# Altium schematic sheet schematic.SchDoc(schematic)
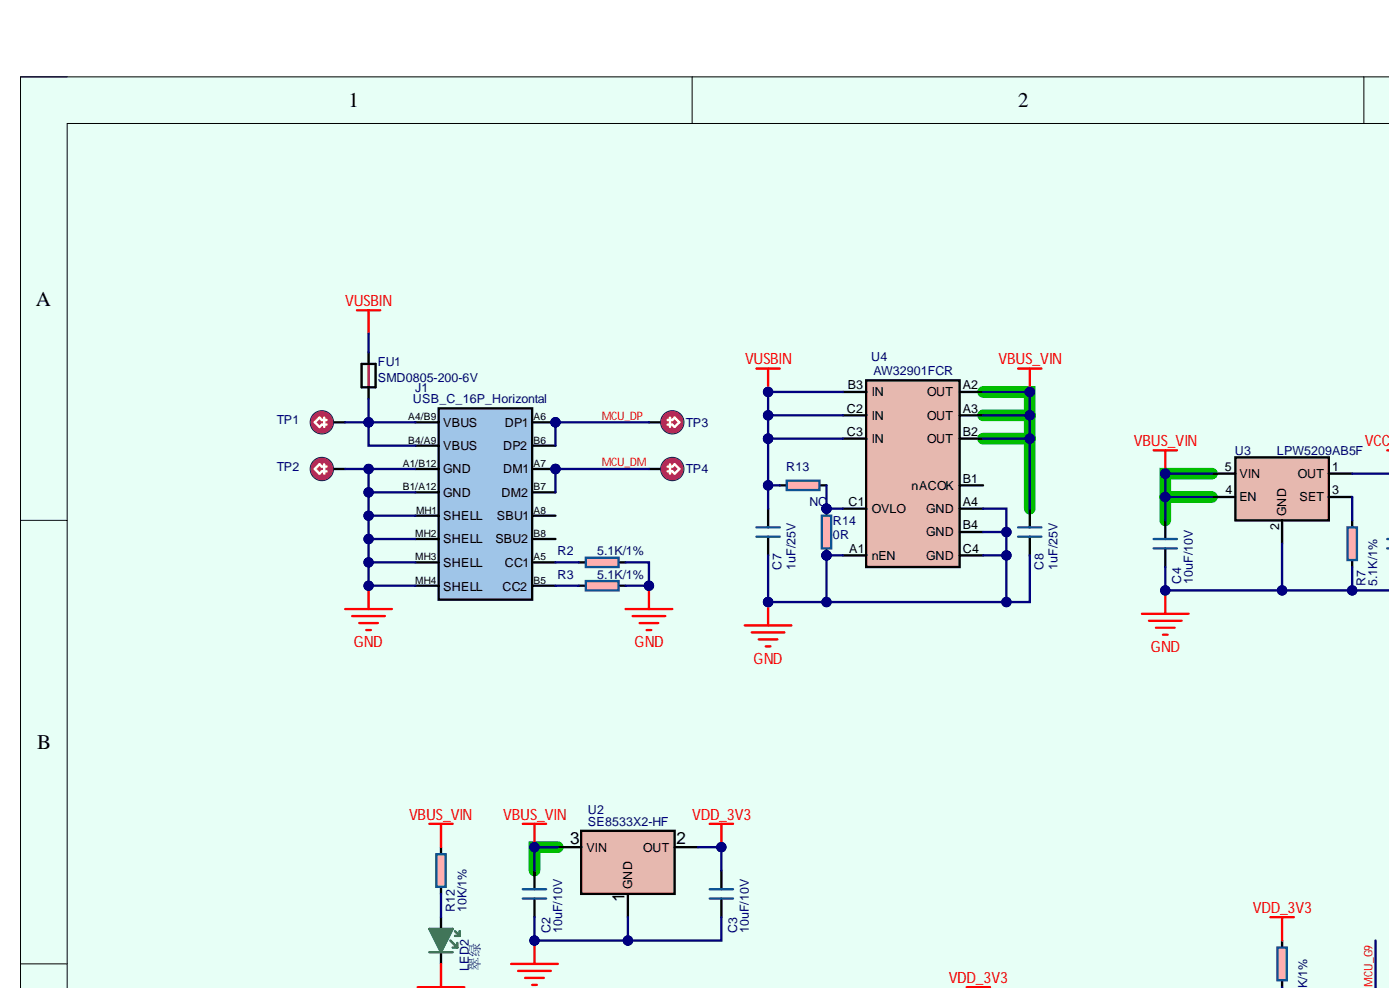
[diagram p0: schematic.SchDoc, top left]
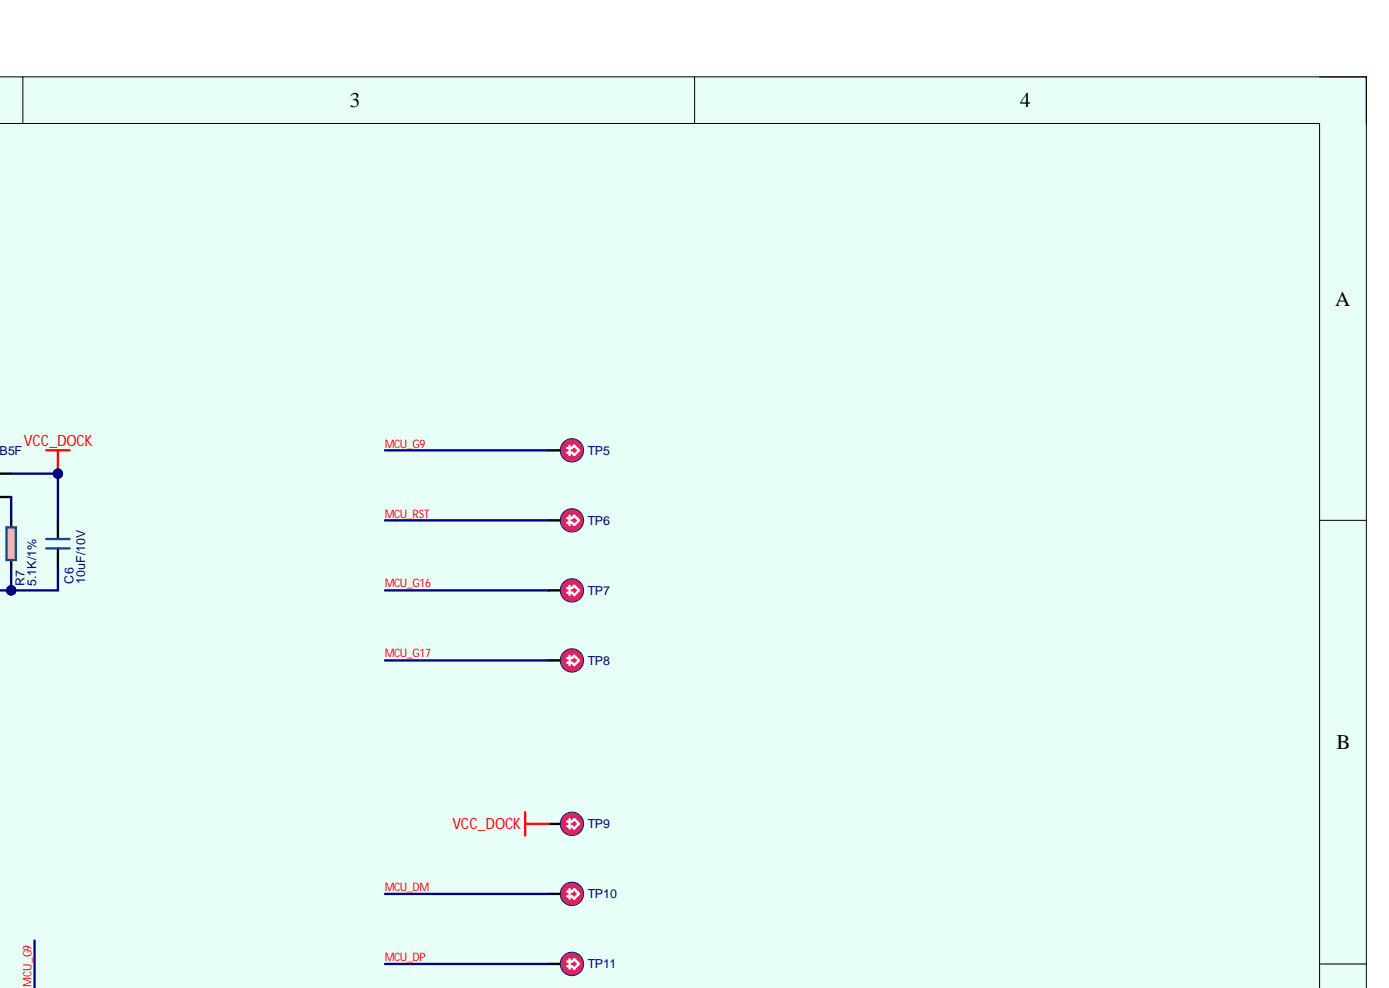
[diagram p1: schematic.SchDoc, top right]
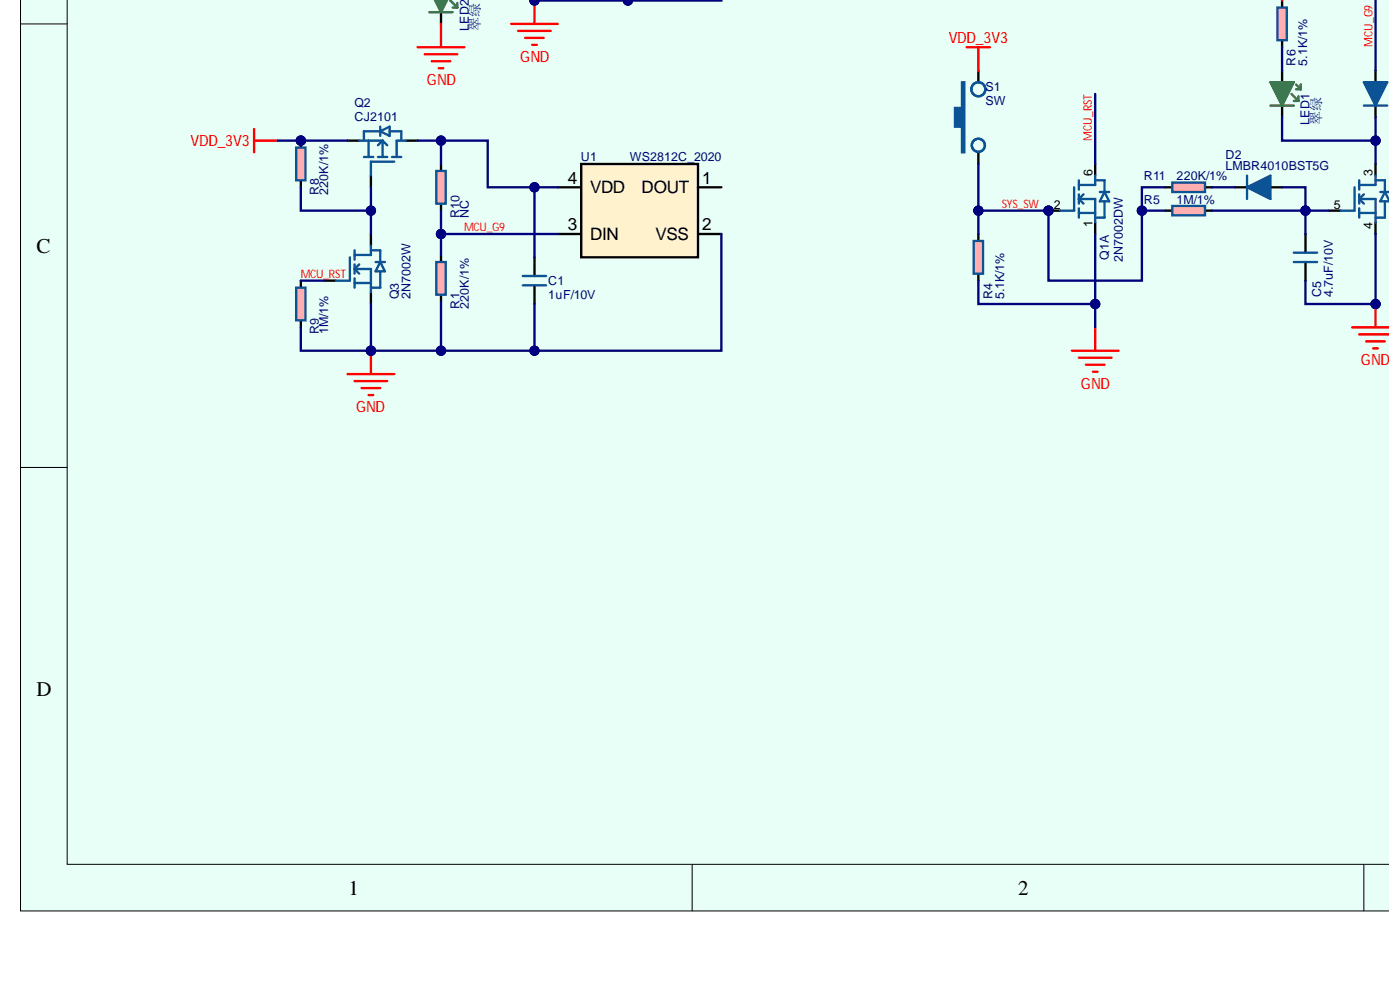
[diagram p2: schematic.SchDoc, bottom left]
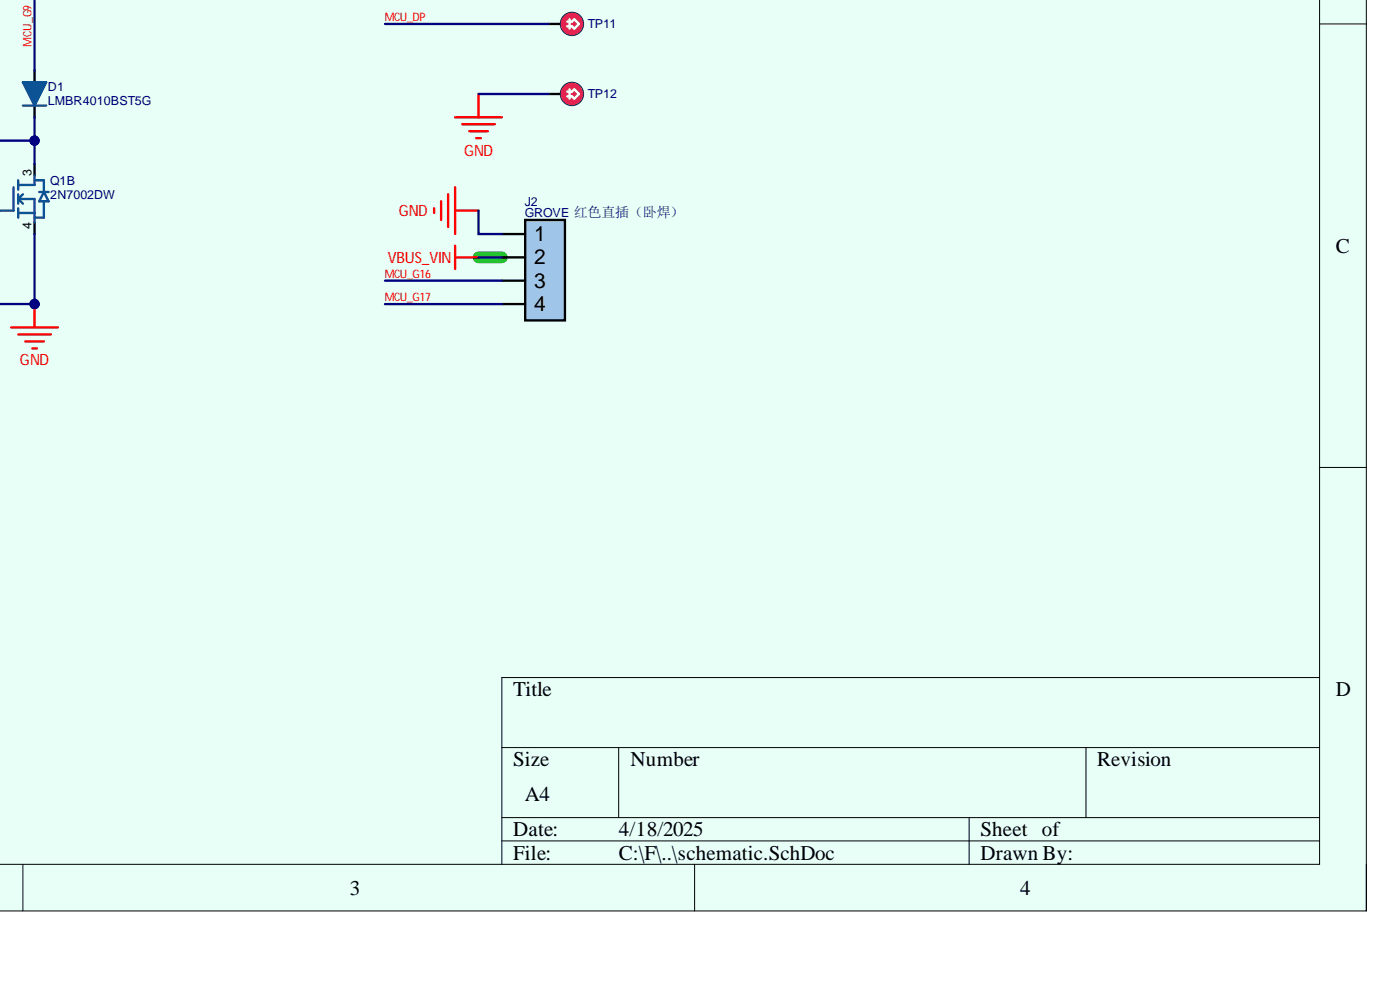
[diagram p3: schematic.SchDoc, bottom right]

## Parts
C1  1uF/10V  [0402C]
C2  10uF/10V  [0603C]
C3  10uF/10V  [0603C]
C4  10uF/10V  [0603C]
C5  4.7uF/10V  [0603C]
C6  10uF/10V  [0603C]
C7  1uF/25V  [0402C]
C8  1uF/25V  [0402C]
D1  LMBR4010BST5G  [SOD323]
D2  LMBR4010BST5G  [SOD323]
FU1  SMD0805-200-6V  [0805R]
J1  USB_C_16P_Horizontal  [USB_C_16P_FEMALE_HOR_SINK_0.8_L7.3]
J2  GROVE 红色直插（卧焊）  [SIP4_DIP_2.0]
LED1  翠绿  [LED_0603]
LED2  翠绿  [LED_0603]
Q1  2N7002DW  [SC70_6]  (parts A,B)
Q2  CJ2101  [SOT323_3/SC70_3_MOS]
Q3  2N7002W  [SOT323_3/SC70_3_MOS]
R1  220K/1%  [0402R]
R2  5.1K/1%  [0402R]
R3  5.1K/1%  [0402R]
R4  5.1K/1%  [0402R]
R5  1M/1%  [0402R]
R6  5.1K/1%  [0402R]
R7  5.1K/1%  [0402R]
R8  220K/1%  [0402R]
R9  1M/1%  [0402R]
R10  NC  [0402R]
R11  220K/1%  [0402R]
R12  10K/1%  [0402R]
R13  NC  [0402R]
R14  0R  [0402R]
S1  SW  [SW_MEDIUM_TURTLE]
TP1  TP  [TP_2*2]
TP2  TP  [TP_2*2]
TP3  TP  [TP_2*2]
TP4  TP  [TP_2*2]
TP5  TP  [TP_1*1]
TP6  TP  [TP_1*1]
TP7  TP  [TP_1*1]
TP8  TP  [TP_1*1]
TP9  TP  [TP_1*1]
TP10  TP  [TP_1*1]
TP11  TP  [TP_1*1]
TP12  TP  [TP_1*1]
U1  WS2812C_2020  [LED_WS2812_2020]
U2  SE8533X2-HF  [SOT23]
U3  LPW5209AB5F  [SOT23_5]
U4  AW32901FCR  [FCQFN12_AW329xx]

## Nets
GND: C1.1 C2.1 C3.1 C4.1 C5.1 C6.1 C7.2 C8.2 J1.A1/B12(GND) J1.B1/A12(GND) J1.MH1(SHELL) J1.MH2(SHELL) J1.MH3(SHELL) J1.MH4(SHELL) J2.1 LED2.2 Q1.1 Q1.4 Q3.S R1.1 R2.2 R3.2 R4.1 R7.1 R9.1 R14.1 TP2.1 TP12.1 U1.2(VSS) U2.1 U3.2(GND) U4.A1(nEN) U4.A4(GND) U4.B4(GND) U4.C4(GND)
VBUS_VIN: C2.2 C4.2 C8.1 J2.2 R12.2 U2.3 U3.4(EN) U3.5(VIN) U4.A2(OUT) U4.A3(OUT) U4.B2(OUT)
VCC_DOCK: C6.2 TP9.1 U3.1(OUT)
VDD_3V3: C3.2 Q2.S R6.2 R8.2 S1.2 U2.2
VUSBIN: C7.1 FU1.2 R13.1 U4.B3(IN) U4.C2(IN) U4.C3(IN)
MCU_DM: J1.A7(DM1) J1.B7(DM2) TP4.1 TP10.1
MCU_DP: J1.A6(DP1) J1.B6(DP2) TP3.1 TP11.1
MCU_G9: D1.1 R1.2 R10.1 TP5.1 U1.3(DIN)
MCU_G16: J2.3 TP7.1
MCU_G17: J2.4 TP8.1
MCU_RST: Q1.6 Q3.G R9.2 TP6.1
SYS_SW: Q1.2 R4.2 R5.2 R11.2 S1.1
NetC1_2: C1.2 Q2.D R10.2 U1.4(VDD)
NetC5_2: C5.2 D2.1 Q1.5 R5.1
NetD1_2: D1.2 LED1.2 Q1.3
NetD2_2: D2.2 R11.1
NetFU1_1: FU1.1 J1.A4/B9(VBUS) J1.B4/A9(VBUS) TP1.1
NetJ1_A5: J1.A5(CC1) R2.1
NetJ1_B5: J1.B5(CC2) R3.1
NetLED1_1: LED1.1 R6.1
NetLED2_1: LED2.1 R12.1
NetQ2_G: Q2.G Q3.D R8.1
NetR7_2: R7.2 U3.3(SET)
NetR13_2: R13.2 R14.2 U4.C1(OVLO)

Not connected: J1 A8(SBU1) B8(SBU2); U1 1(DOUT); U4 B1(nACOK)

## Library data of the parts
C1-C8 = CAP: Component Kind: Standard; Library Name: LibMySelf_SCHLIB.SchLib
D1 D2 = VD: Component Kind: Standard; Library Name: LibMySelf_SCHLIB.SchLib
FU1 = FUSE: Component Kind: Standard; Library Name: LibMySelf_SCHLIB.SchLib
J1 = USB_TYPEC_16P_Horizontal_4MP: Component Kind: Standard; Library Name: LibMySelf_SCHLIB.SchLib
J2 = CON4: Component Kind: Standard; Library Name: LibMySelf_SCHLIB.SchLib
LED1 LED2 = LED_GREEN: Component Kind: Standard; Library Name: LibMySelf_SCHLIB.SchLib
Q1 = MOS_Dual_N_Generic_6pin: Component Kind: Standard; Library Name: LibMySelf_SCHLIB.SchLib
Q2 = MOS_P: Component Kind: Standard; Library Name: LibMySelf_SCHLIB.SchLib
Q3 = MOS_N: Component Kind: Standard; Library Name: LibMySelf_SCHLIB.SchLib
R1-R14 = RES: Component Kind: Standard; Library Name: LibMySelf_SCHLIB.SchLib
S1 = SW_PB: Component Kind: Standard; Library Name: LibMySelf_SCHLIB.SchLib
TP1-TP12 = TESTPOINT_SCH: Component Kind: Standard; Library Name: LibMySelf_SCHLIB.SchLib
U1 = WS2812C: Component Kind: Standard; Library Name: LibMySelf_SCHLIB.SchLib
U2 = XC6206: Component Kind: Standard; Library Name: LibMySelf_SCHLIB.SchLib
U3 = MT9700: COMP. with ME1502A; Component Kind: Standard; Library Name: LibMySelf_SCHLIB.SchLib
U4 = AW32901: Over-Voltage Protection Load Switch with Surge Protection; Component Kind: Standard; Library Name: LibMySelf_SCHLIB.SchLib
